# Altium schematic sheet OnePhaseMain.SchDoc
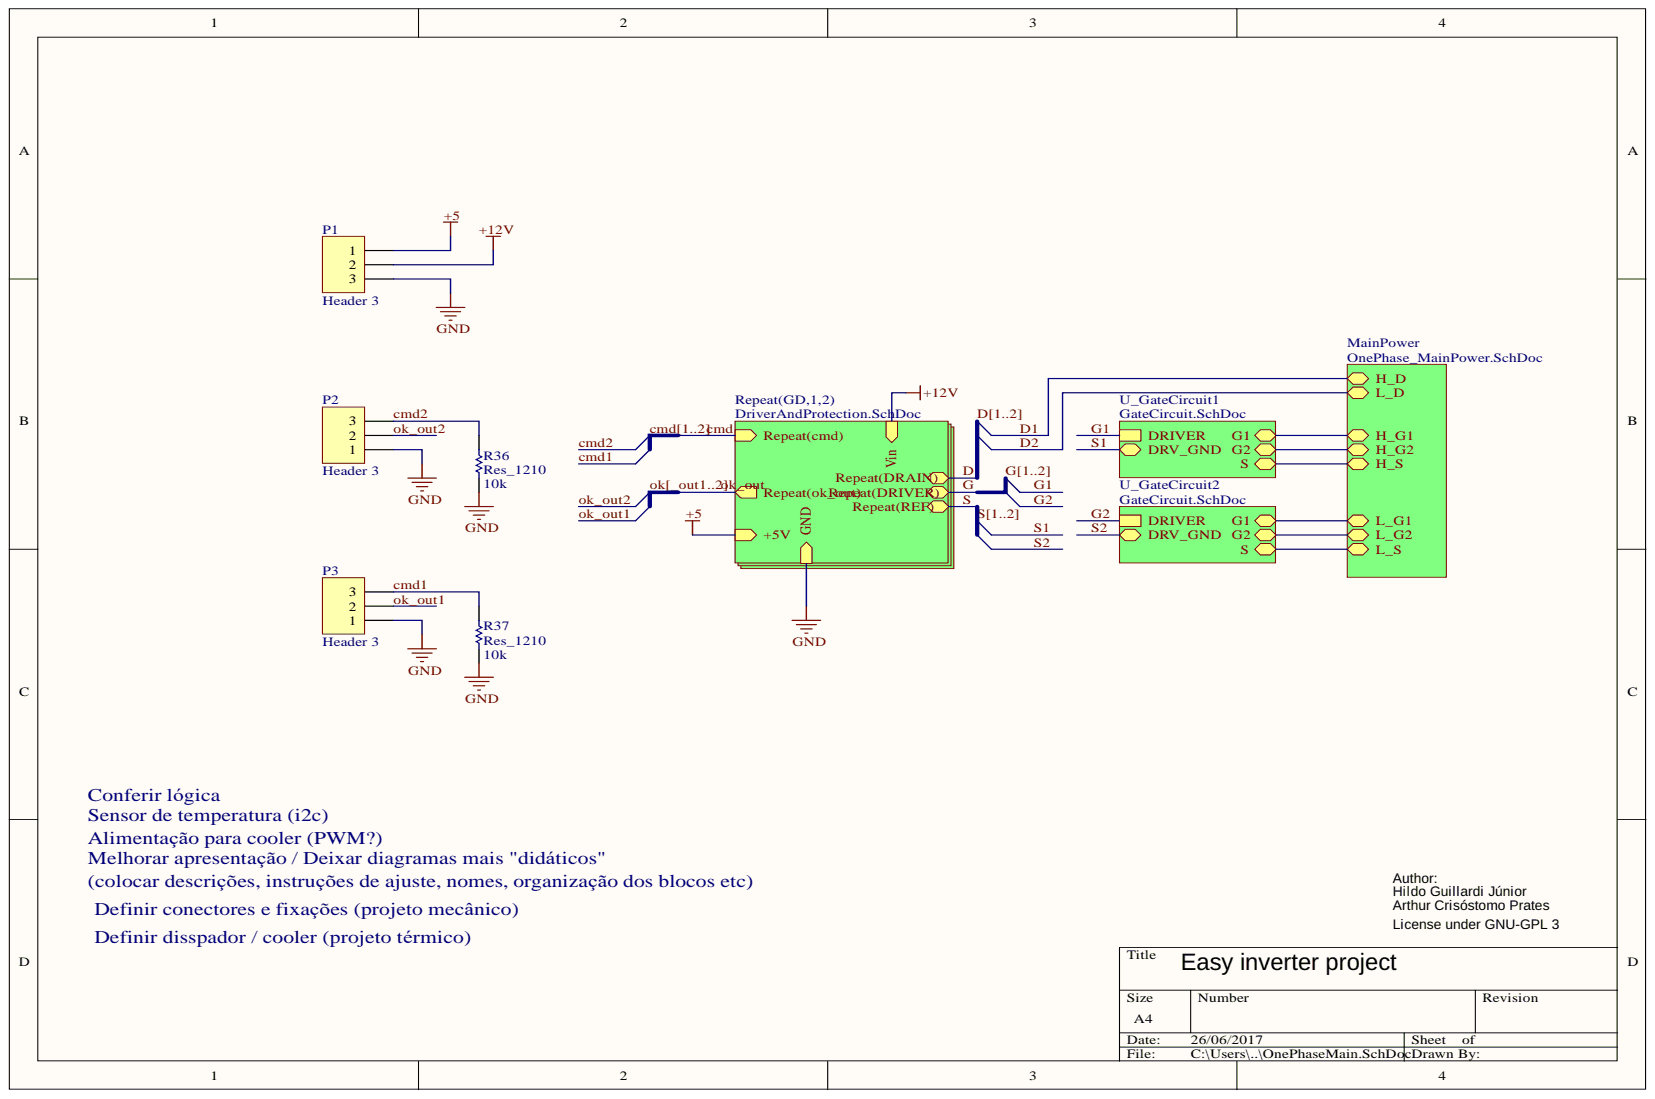
[diagram p0: OnePhaseMain.SchDoc]

## Parts
P1  Header 3  [3 Pin  Borner]
P2  Header 3  [HDR1X3]
P3  Header 3  [HDR1X3]
R36  Res_1210  value 10k  [0805_W]
R37  Res_1210  value 10k  [0805_W]

## Nets
+5: P1.1
+12V: P1.2
GND: P1.3 P2.1 P3.1 R36.2 R37.2
CMD1: P3.3 R37.1  [also labelled CMD]
CMD2: P2.3 R36.1  [also labelled CMD]
OK_OUT1: P3.2
OK_OUT2: P2.2
D1:  [also labelled D]
D2:  [also labelled D]
G1:  [also labelled G]
G2:  [also labelled G]
H_G1:
H_G2:
H_S:
L_G1:
L_G2:
L_S:
OK[_OUT1..2]:
S1:  [also labelled S]
S2:  [also labelled S]

## Library data of the parts
P1-P3 = Header 3: Header, 3-Pin; Component Kind: Standard; LatestRevisionDate: 17-Jul-2002; LatestRevisionNote: Re-released for DXP Platform.; Library Name: Miscellaneous Connectors.IntLib; Signal Integrity: Connector; Publisher: Altium Limited
R36 R37 = Res_0805: Resistor; Manufacturer: Generic Components; Class: Passive; Component Kind: Standard; Published: 08/06/2000; Signal Integrity: Res1; Simulation: RESISTOR; SubClass: Resistor; Library Name: Inversor_LCEE.SchLib; Publisher: Altium Hobart Technology Centre
